# Altium schematic sheet VCU_Schematic.SchDoc(VCU_Schematic)
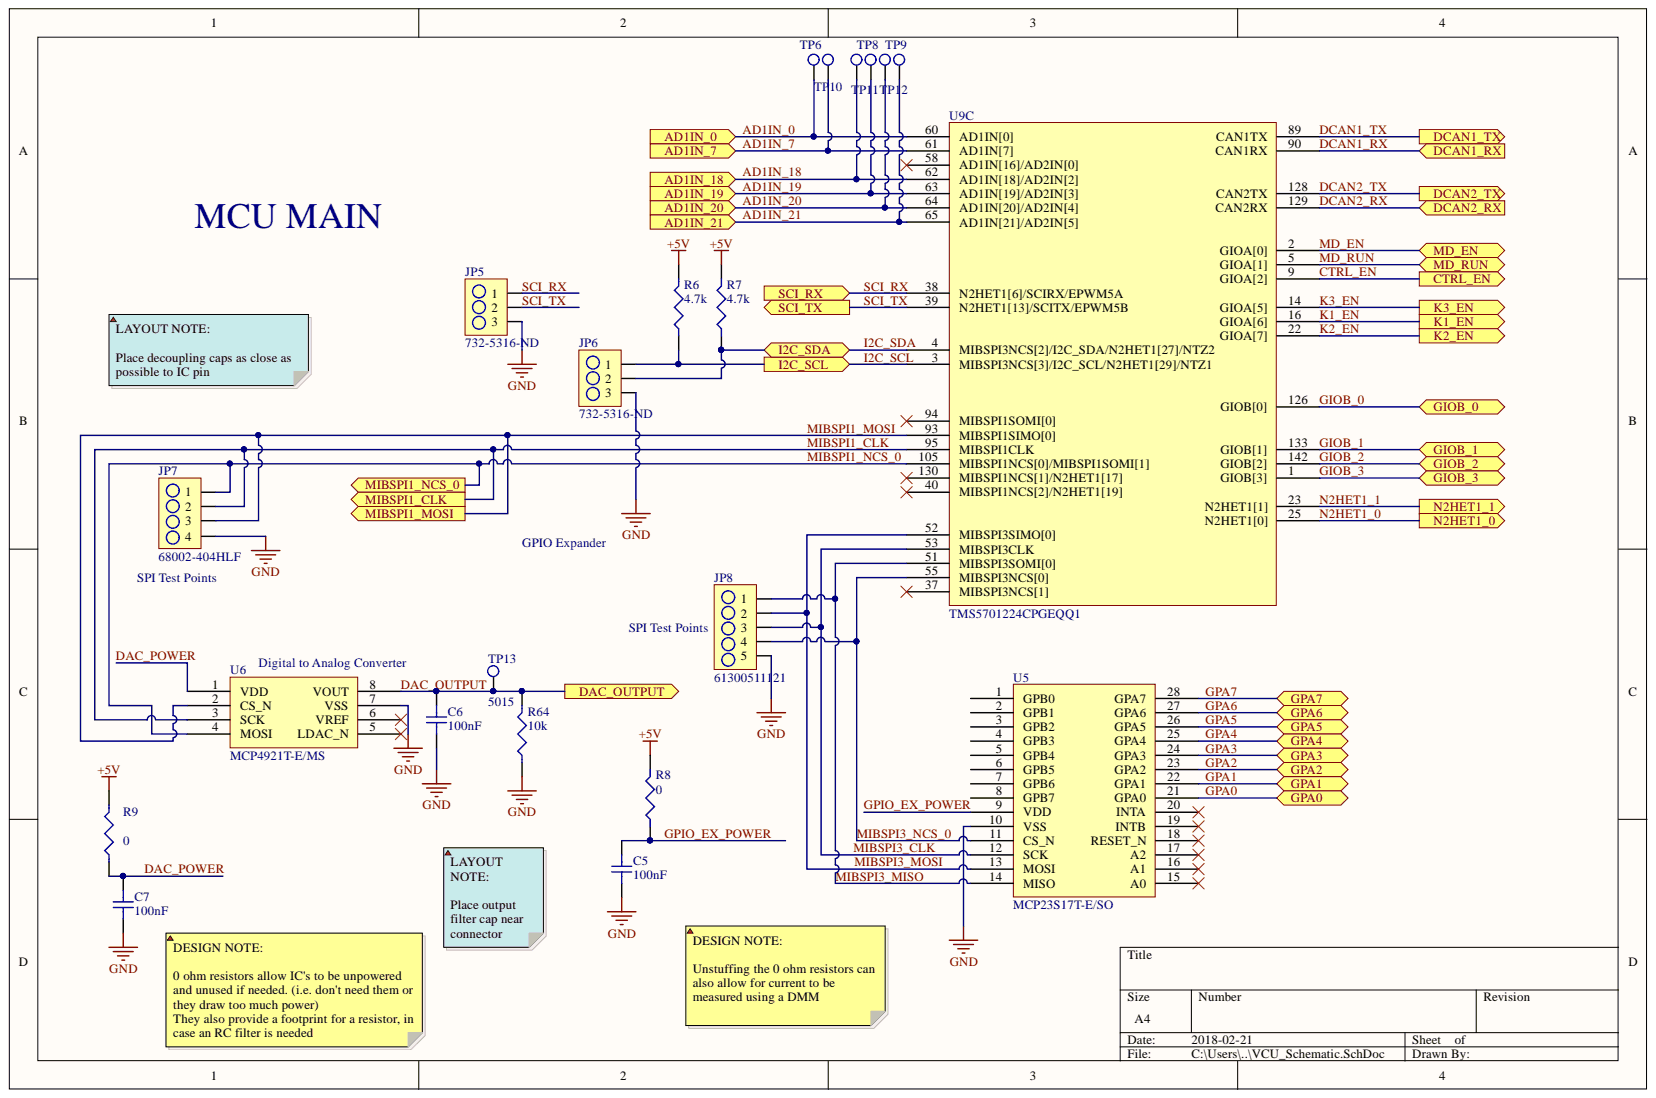
[diagram p0: VCU_Schematic.SchDoc]

## Parts
C5  100nF  [C0805]
C6  100nF  [C0805]
C7  100nF  [C0805]
JP5  732-5316-ND  [3PIN_HEADER]
JP6  732-5316-ND  [3PIN_HEADER]
JP7  68002-404HLF  [4PIN_HEADER]
JP8  61300511121  [5PIN_HEADER]
R6  4.7k  [R0805]
R7  4.7k  [R0805]
R8  0  [R0805]
R9  0  [R0805]
R64  10k  [R0805]
TP6  5015  [TP_HOOK]  (value not drawn)
TP8  5015  [TP_HOOK]  (value not drawn)
TP9  5015  [TP_HOOK]  (value not drawn)
TP10  5015  [TP_HOOK]  (value not drawn)
TP11  5015  [TP_HOOK]  (value not drawn)
TP12  5015  [TP_HOOK]  (value not drawn)
TP13  5015  [TP_HOOK]
U5  MCP23S17T-E/SO  [28-SOIC_MCP23S17]
U6  MCP4921T-E/MS  [8-MSOP_MCP4921]
U9  TMS5701224CPGEQQ1  [LQFP-144]  (part C)

## Ports
AD1IN_0, AD1IN_7, AD1IN_18, AD1IN_19, AD1IN_20, AD1IN_21, CTRL_EN, DAC_OUTPUT, DCAN1_RX, DCAN1_TX, DCAN2_RX, DCAN2_TX, GIOB_0, GIOB_1, GIOB_2, GIOB_3, GPA0, GPA1, GPA2, GPA3, GPA4, GPA5, GPA6, GPA7, I2C_SCL, I2C_SDA, K1_EN, K2_EN, K3_EN, MD_EN, MD_RUN, MIBSPI1_CLK, MIBSPI1_MOSI, MIBSPI1_NCS_0, N2HET1_0, N2HET1_1, SCI_RX, SCI_TX

## Nets
+5V: R6.2 R7.2 R8.2 R9.2
GND: C5.1 C6.1 C7.1 JP5.3 JP6.3 JP7.4 JP8.5 R64.1 U5.10(VSS) U6.7(VSS)
AD1IN_0: TP6.1 U9.60(AD1IN[0]) ; port AD1IN_0
AD1IN_7: TP10.1 U9.61(AD1IN[7]) ; port AD1IN_7
AD1IN_18: TP11.1 U9.62(AD1IN[18]/AD2IN[2]) ; port AD1IN_18
AD1IN_19: TP8.1 U9.63(AD1IN[19]/AD2IN[3]) ; port AD1IN_19
AD1IN_20: TP12.1 U9.64(AD1IN[20]/AD2IN[4]) ; port AD1IN_20
AD1IN_21: TP9.1 U9.65(AD1IN[21]/AD2IN[5]) ; port AD1IN_21
CTRL_EN: U9.9(GIOA[2]) ; port CTRL_EN
DAC_OUTPUT: C6.2 R64.2 TP13.1 U6.8(VOUT) ; port DAC_OUTPUT
DAC_POWER: C7.2 R9.1 U6.1(VDD)
DCAN1_RX: U9.90(CAN1RX) ; port DCAN1_RX
DCAN1_TX: U9.89(CAN1TX) ; port DCAN1_TX
DCAN2_RX: U9.129(CAN2RX) ; port DCAN2_RX
DCAN2_TX: U9.128(CAN2TX) ; port DCAN2_TX
GIOB_0: U9.126(GIOB[0]) ; port GIOB_0
GIOB_1: U9.133(GIOB[1]) ; port GIOB_1
GIOB_2: U9.142(GIOB[2]) ; port GIOB_2
GIOB_3: U9.1(GIOB[3]) ; port GIOB_3
GPA0: U5.21(GPA0) ; port GPA0
GPA1: U5.22(GPA1) ; port GPA1
GPA2: U5.23(GPA2) ; port GPA2
GPA3: U5.24(GPA3) ; port GPA3
GPA4: U5.25(GPA4) ; port GPA4
GPA5: U5.26(GPA5) ; port GPA5
GPA6: U5.27(GPA6) ; port GPA6
GPA7: U5.28(GPA7) ; port GPA7
GPIO_EX_POWER: C5.2 R8.1 U5.9(VDD)
I2C_SCL: JP6.1 R6.1 U9.3(MIBSPI3NCS[3]/I2C_SCL/N2HET1[29]/NTZ1) ; port I2C_SCL
I2C_SDA: JP6.2 R7.1 U9.4(MIBSPI3NCS[2]/I2C_SDA/N2HET1[27]/NTZ2) ; port I2C_SDA
K1_EN: U9.16(GIOA[6]) ; port K1_EN
K2_EN: U9.22(GIOA[7]) ; port K2_EN
K3_EN: U9.14(GIOA[5]) ; port K3_EN
MD_EN: U9.2(GIOA[0]) ; port MD_EN
MD_RUN: U9.5(GIOA[1]) ; port MD_RUN
MIBSPI1_CLK: JP7.2 U6.3(SCK) U9.95(MIBSPI1CLK) ; port MIBSPI1_CLK
MIBSPI1_MOSI: JP7.3 U6.2(CS_N) U9.93(MIBSPI1SIMO[0]) ; port MIBSPI1_MOSI
MIBSPI1_NCS_0: JP7.1 U6.4(MOSI) U9.105(MIBSPI1NCS[0]/MIBSPI1SOMI[1]) ; port MIBSPI1_NCS_0
MIBSPI3_CLK: JP8.3 U5.12(SCK) U9.53(MIBSPI3CLK)
MIBSPI3_MISO: JP8.1 U5.14(MISO) U9.51(MIBSPI3SOMI[0])
MIBSPI3_MOSI: JP8.2 U5.13(MOSI) U9.52(MIBSPI3SIMO[0])
MIBSPI3_NCS_0: JP8.4 U5.11(CS_N) U9.55(MIBSPI3NCS[0])
N2HET1_0: U9.25(N2HET1[0]) ; port N2HET1_0
N2HET1_1: U9.23(N2HET1[1]) ; port N2HET1_1
SCI_RX: JP5.1 U9.38(N2HET1[6]/SCIRX/EPWM5A) ; port SCI_RX
SCI_TX: JP5.2 U9.39(N2HET1[13]/SCITX/EPWM5B) ; port SCI_TX

Not connected: U5 1(GPB0) 2(GPB1) 3(GPB2) 4(GPB3) 5(GPB4) 6(GPB5) 7(GPB6) 8(GPB7) 15(A0) 16(A1) 17(A2) 18(RESET_N) 19(INTB) 20(INTA); U6 5(LDAC_N) 6(VREF); U9 37(MIBSPI3NCS[1]) 40(MIBSPI1NCS[2]/N2HET1[19]) 58(AD1IN[16]/AD2IN[0]) 94(MIBSPI1SOMI[0]) 130(MIBSPI1NCS[1]/N2HET1[17])

## Library data of the parts
C5-C7 = GRM21B7U1A104JA01L: CAP MLCC 100nF 10Volts U2J 5%; Manufacturer: Murata; Voltage Rating (DC): 10V; Width: 0.049inch; Voltage Rating: 10V; Tolerance: 5%; Thickness: 0.053inch; Termination: SMD/SMT; Supplier Part Number 1: 490-11592-6-ND; Supplier 1: Digi-Key; Stock 1: 6000; Radiation Hardening: No; Pricing 1: 1=0.46, 10=0.32, 100=0.192, 500=0.144, 1000=0.1216 (USD); Packaging: Tape and Reel; Package Quantity: 3000; Mount: Surface Mount; Min Operating Temperature: -55°C; Max Operating Temperature: 125°C; Manufacturer Part Number: GRM21B7U1A104JA01L; Length: 2mm; Height: 1.25mm; Dielectric: U2J; Depth: 1.25mm; ComponentLink3URL: https://datasheet.ciiva.com/11618/product-11618924.pdf; ComponentLink3Description: https://datasheet.ciiva.com/11618/product-11618924.pdf; ComponentLink2URL: https://datasheet.ciiva.com/17451/product-17451845.pdf; ComponentLink2Description: https://datasheet.ciiva.com/17451/product-17451845.pdf; ComponentLink1URL: https://datasheet.ciiva.com/5424/product-5424660.pdf; ComponentLink1Description: https://datasheet.ciiva.com/5424/product-5424660.pdf; Component Kind: Standard; Case Code (Metric): 2012; Case Code (Imperial): 0805; Case/Package: 0805; Capacitance: 100nF; Library Name: PHANTOM_LIBRARY.SchLib
JP5 JP6 = 61300311121: CONN_HeaderPins3pos WURTH ELEKTRONIK Board-To-Board Connector, Vertical, WR-PHD Series, Through Hole, Header, 3 Contacts, 2.54 mm; Manufacturer: Wurth Electronics; Pitch: 0.1inch; Number of Positions: 3; Gender: Male; Connector Type: Male Pin; Termination: Solder; Supplier Part Number 1: 732-5316-ND; Supplier 1: Digi-Key; Stock 1: 57026; REACH SVHC: Unknown; Pricing 1: 1=0.13, 10=0.106, 25=0.088, 50=0.074, 100=0.067, 1000=0.061 (USD); Packaging: Bulk; Number of Rows: 1; Number of Contacts: 3; Mount: Through Hole; Manufacturer Part Number: 61300311121; Lead Free: Lead Free; Contact Plating: Gold; Contact Mating Length: 0.236inch; Contact Material: Copper; ComponentLink1URL: http://www.farnell.com/cad/1737268.pdf; ComponentLink1Description: http://www.farnell.com/cad/1737268.pdf; Component Kind: Standard; Colour: Black; Case/Package: 100; Library Name: PHANTOM_LIBRARY.SchLib
JP7 = 68002-404HLF: CONN_HeaderPins4pos 4 Positions Header, Unshrouded Connector 0.100" (2.54mm) Through Hole Tin; Manufacturer: Amphenol FCI; Voltage Rating: 1.5kV; Number of Positions: 4; Mating Post Length: 5.84mm; Insulation Resistance: 5GR; Gender: Male; Current Rating: 3A; Connector Type: Male Pin; Termination Post Length: 3.81mm; Termination: Solder; Supplier Part Number 1: 609-3305-ND; Supplier 1: Digi-Key; Stock 1: 4956; Radiation Hardening: No; Pricing 1: 1=0.21, 10=0.195, 100=0.1352, 500=0.1144, 1000=0.0936, 5000=0.0832, 10000=0.0754 (USD); Pitch: 2.54mm; Packaging: Bulk; Package Quantity: 100; Orientation: Straight; Number of Rows: 1; Number of Contacts: 4; Mount: Through Hole; Min Operating Temperature: -65°C; Max Voltage Rating (AC): 1.5kV; Max Operating Temperature: 125°C; Manufacturer Part Number: 68002-404HLF; Length: 10.16mm; Housing Material: Thermoplastic; Housing Colour: Black; Flammability Rating: UL94 V-0; Depth: 2.41mm; Contact Plating: Nickel,Tin; Contact Mating Length: 0.23inch; Contact Material: Bronze; Contact Gender: Male; ComponentLink3URL: https://datasheet.ciiva.com/728/68000-728877.pdf; ComponentLink3Description: https://datasheet.ciiva.com/728/68000-728877.pdf; ComponentLink2URL: https://datasheet.ciiva.com/16725/68000-16725993.pdf; ComponentLink2Description: https://datasheet.ciiva.com/16725/68000-16725993.pdf; ComponentLink1URL: https://datasheet.ciiva.com/9474/68000-9474358.pdf; ComponentLink1Description: https://datasheet.ciiva.com/9474/68000-9474358.pdf; Component Kind: Standard; Colour: Black; Library Name: PHANTOM_LIBRARY.SchLib
JP8 = 61300511121: CONN_HeaderPins5pos WURTH ELEKTRONIK         61300511121            Board-To-Board Connector, Vertical, WR-PHD Series, Through Hole, Header, 5 Contacts, 2.54 mm; Manufacturer: Wurth Electronics; Pitch: 0.1inch; Number of Positions: 5; Gender: Male; Connector Type: Male Pin; Termination: Solder; Supplier Part Number 1: 732-5318-ND; Supplier 1: Digi-Key; Stock 1: 13004; REACH SVHC: Unknown; Pricing 1: 1=0.25, 10=0.209, 25=0.174, 50=0.145, 100=0.132, 1000=0.12 (USD); Packaging: Bulk; Number of Rows: 1; Number of Contacts: 5; Mount: Through Hole; Manufacturer Part Number: 61300511121; Lead Free: Lead Free; Contact Plating: Gold; Contact Mating Length: 0.236inch; Contact Material: Copper; ComponentLink1URL: http://www.farnell.com/cad/1737268.pdf; ComponentLink1Description: http://www.farnell.com/cad/1737268.pdf; Component Kind: Standard; Colour: Black; Library Name: PHANTOM_LIBRARY.SchLib
R6 R7 = RC0805FR-074K7L: RES SMD 4.7K OHM 1% 1/8W 0805; Manufacturer: Yageo; Voltage Rating (DC): 150V; Temperature Coefficient: 100ppm/°C; Resistance: 4.7KR; Power Rating: 125mW; Width: 0.049inch; Voltage Rating: 150V; Tolerance: 1%; Termination: SMD/SMT; Supplier Part Number 1: 311-4.70KCRDKR-ND; Supplier 1: Digi-Key; Stock 1: 2632902; REACH SVHC: No SVHC; Pricing 1: 1=0.1, 10=0.022, 100=0.0088, 1000=0.004, 2500=0.0034 (USD); Packaging: Tape and Reel; Package Quantity: 5000; Number of Terminations: 2; Number of Pins: 2; Min Operating Temperature: -55°C; Military Standard: Not; Max Power Dissipation: 125mW; Max Operating Temperature: 155°C; Manufacturer Part Number: RC0805FR-074K7L; Length: 0.079inch; Lead Free: Lead Free; Height: 0.024inch; Depth: 1.25mm; Composition: Thick Film; ComponentLink6URL: https://datasheet.ciiva.com/46447/444164-46447583.pdf; ComponentLink6Description: https://datasheet.ciiva.com/46447/444164-46447583.pdf; ComponentLink5URL: https://datasheet.ciiva.com/6547/pyu-r-int-thick-7-6547263.pdf; ComponentLink5Description: https://datasheet.ciiva.com/6547/pyu-r-int-thick-7-6547263.pdf; ComponentLink4URL: https://datasheet.ciiva.com/7941/444164-7941806.pdf; ComponentLink4Description: https://datasheet.ciiva.com/7941/444164-7941806.pdf; ComponentLink3URL: https://datasheet.ciiva.com/7941/1686128-7941805.pdf; ComponentLink3Description: https://datasheet.ciiva.com/7941/1686128-7941805.pdf; ComponentLink2URL: https://datasheet.ciiva.com/7941/444164-7941804.pdf; ComponentLink2Description: https://datasheet.ciiva.com/7941/444164-7941804.pdf; ComponentLink1URL: https://datasheet.ciiva.com/11808/0900766b8106beab-11808155.pdf; ComponentLink1Description: https://datasheet.ciiva.com/11808/0900766b8106beab-11808155.pdf; Component Kind: Standard; Case Code (Metric): 2012; Case Code (Imperial): 0805; Case/Package: 0805; Library Name: PHANTOM_LIBRARY.SchLib
R8 R9 = RC0805JR-070RL: RES SMD 0 OHM 1/8W 0805; Manufacturer: Yageo; Voltage Rating (DC): 150V; Tolerance: 5%; Temperature Coefficient: 200ppm/°C; Resistance: 0mR; Power Rating: 125mW; Width: 1.25mm; Voltage Rating: 150V; Termination: SMD/SMT; Supplier Part Number 1: 311-0.0ARDKR-ND; Supplier 1: Digi-Key; Stock 1: 5922085; REACH SVHC: Unknown; Radiation Hardening: No; Pricing 1: 1=0.1, 10=0.018, 25=0.0128, 50=0.0096, 100=0.0071, 250=0.0054, 500=0.0044, 1000=0.0032 (USD); Packaging: Tape and Reel; Number of Pins: 2; Min Operating Temperature: -55°C; Military Standard: Not; Max Operating Temperature: 155°C; Manufacturer Part Number: RC0805JR-070RL; Length: 2mm; Lead Free: Lead Free; Height: 0.5mm; Depth: 1.25mm; Contact Plating: Tin,Matte; Composition: Thick Film; ComponentLink5URL: https://datasheet.ciiva.com/15190/getdatasheetpartid-330685-15190028.pdf; ComponentLink5Description: https://datasheet.ciiva.com/15190/getdatasheetpartid-330685-15190028.pdf; ComponentLink4URL: https://datasheet.ciiva.com/7941/1738694-7941863.pdf; ComponentLink4Description: https://datasheet.ciiva.com/7941/1738694-7941863.pdf; ComponentLink3URL: https://datasheet.ciiva.com/11819/0900766b8106beab-11819430.pdf; ComponentLink3Description: https://datasheet.ciiva.com/11819/0900766b8106beab-11819430.pdf; ComponentLink2URL: https://datasheet.ciiva.com/7941/1738694-7941862.pdf; ComponentLink2Description: https://datasheet.ciiva.com/7941/1738694-7941862.pdf; ComponentLink1URL: https://datasheet.ciiva.com/7941/1738694-7941861.pdf; ComponentLink1Description: https://datasheet.ciiva.com/7941/1738694-7941861.pdf; Component Kind: Standard; Case Code (Metric): 2012; Case Code (Imperial): 0805; Case/Package: 0805; Library Name: PHANTOM_LIBRARY.SchLib
R64 = RC0805FR-071KL: RES SMD 10K OHM 1% 1/8W 0805; Manufacturer: Yageo Phycomp; Voltage Rating (DC): 150V; Temperature Coefficient: 100ppm/°C; Resistance: 1KR; Power Rating: 125mW; Width: 1.25mm; Tolerance: 1%; Supplier 1: Digi-Key; Stock 1: 5527973; REACH SVHC: No SVHC; Pricing 1: 1=0.1, 10=0.022, 100=0.0088, 1000=0.004, 2500=0.0034 (USD); Packaging: Cut Tape; Number of Pins: 2; Min Operating Temperature: -55°C; Max Operating Temperature: 155°C; Manufacturer Part Number: RC0805FR-071KL; Length: 2mm; Lead Free: Lead Free; Height: 0.5mm; Depth: 1.25mm; Component Kind: Standard; Case/Package: 0805; Library Name: PHANTOM_LIBRARY.SchLib
TP6 TP8-TP13 = 5015: TEST POINT, PCB, SMT; Manufacturer: Keystone Electronics; Number of Ports: 1; Thickness: 0.01inch; Termination: Solder; Supplier Part Number 1: 36-5015CT-ND; Supplier 1: Digi-Key; Stock 1: 1937775; REACH SVHC: No SVHC; Pricing 1: 1=0.44, 10=0.421, 25=0.3604, 50=0.3064, 100=0.2944, 250=0.2644, 500=0.2524 (USD); Packaging: Tape and Reel; Package Quantity: 1; Orientation: Straight; Mount: Surface Mount; Material: Bronze,Silver; Manufacturer Part Number: 5015; Length: 2.66mm; Lead Free: Lead Free; Height: 0.06inch; Depth: 1.01mm; Contact Plating: Tin,Silver,Lead; Contact Material: Bronze; ComponentLink4URL: https://datasheet.ciiva.com/16883/70182998-16883734.pdf; ComponentLink4Description: https://datasheet.ciiva.com/16883/70182998-16883734.pdf; ComponentLink3URL: https://datasheet.ciiva.com/1123/5015-1123862.pdf; ComponentLink3Description: https://datasheet.ciiva.com/1123/5015-1123862.pdf; ComponentLink2URL: https://datasheet.ciiva.com/2917/5015-2917617.pdf; ComponentLink2Description: https://datasheet.ciiva.com/2917/5015-2917617.pdf; ComponentLink1URL: https://datasheet.ciiva.com/2917/5015-2917616.pdf; ComponentLink1Description: https://datasheet.ciiva.com/2917/5015-2917616.pdf; Component Kind: Standard; Colour: White; Library Name: PHANTOM_LIBRARY.SchLib
U5 = MCP23S17T-E/SO: IC I/O Expander, 16bit, 10 MHz, SPI, 4.5 V, 5.5 V, SOIC; Manufacturer: Microchip; Power Dissipation: 700mW; Number of I/Os: 16; Number of Channels: 1; Nominal Supply Current: 1mA; Min Supply Voltage: 4.5V; Max Supply Voltage: 5.5V; Max Frequency: 10MHz; Interface: SPI,Serial; Supplier Part Number 1: MCP23S17T-E/SODKR-ND; Supplier 1: Digi-Key; Stock 1: 6929; REACH SVHC: No SVHC; Pricing 1: 1=1.32, 25=1.1024, 100=0.9975 (USD); Packaging: Tape and Reel; Package Quantity: 1600; Number of Pins: 28; Number of Bits: 16; Mount: Surface Mount; Min Operating Temperature: -40°C; Max Operating Temperature: 125°C; Manufacturer Part Number: MCP23S17T-E/SO; Lead Free: Lead Free; Frequency: 10MHz; ComponentLink6URL: https://datasheet.ciiva.com/12266/21952b-12266927.pdf; ComponentLink6Description: https://datasheet.ciiva.com/12266/21952b-12266927.pdf; ComponentLink5URL: https://datasheet.ciiva.com/1505/21952b-1505037.pdf; ComponentLink5Description: https://datasheet.ciiva.com/1505/21952b-1505037.pdf; ComponentLink4URL: https://datasheet.ciiva.com/16617/21952b-16617644.pdf; ComponentLink4Description: https://datasheet.ciiva.com/16617/21952b-16617644.pdf; ComponentLink3URL: https://datasheet.ciiva.com/16221/filehandler-aspxddocname-en023709-16221082.pdf; ComponentLink3Description: https://datasheet.ciiva.com/16221/filehandler-aspxddocname-en023709-16221082.pdf; ComponentLink2URL: https://datasheet.ciiva.com/1495/12179-1495927.pdf; ComponentLink2Description: https://datasheet.ciiva.com/1495/12179-1495927.pdf; ComponentLink1URL: https://datasheet.ciiva.com/1780/21952b-1780739.pdf; ComponentLink1Description: https://datasheet.ciiva.com/1780/21952b-1780739.pdf; Component Kind: Standard; Case/Package: SOIC; Library Name: PHANTOM_LIBRARY.SchLib
U6 = MCP4921T-E/MS: IC Digital to Analog Converters - DAC Sgl 12-bit SPI int; Manufacturer: Microchip; Supply Voltage: 5V; Supply Type: Single; Settling Time: 4.5us; Resolution: 12b; Number of DAC Channels: 1; Number of Bits: 12; Nominal Supply Current: 175uA; Min Supply Voltage: 2.7V; Max Supply Voltage: 5.5V; Interface: SPI,Serial; Integral Nonlinearity (INL): 12LSB; Input Offset Voltage (Vos): 820uV; Supplier Part Number 1: MCP4921T-E/MSCT-ND; Supplier 1: Digi-Key; Stock 1: 5999; REACH SVHC: No SVHC; Radiation Hardening: No; Pricing 1: 1=2.07, 25=1.722, 100=1.5645 (USD); Packaging: Tape and Reel; Package Quantity: 1; Number of Pins: 8; Number of Converters: 1; Number of Channels: 1; Mount: Surface Mount; Min Operating Temperature: -40°C; Max Operating Temperature: 125°C; Manufacturer Part Number: MCP4921T-E/MS; Lead Free: Lead Free; ComponentLink7URL: https://datasheet.ciiva.com/41459/001083287-da-01-en-ic-dac-12-w-spi-mcp4921t-e-ms-msop-8-mcp-41459046.pdf; ComponentLink7Description: https://datasheet.ciiva.com/41459/001083287-da-01-en-ic-dac-12-w-spi-mcp4921t-e-ms-msop-8-mcp-41459046.pdf; ComponentLink6URL: https://datasheet.ciiva.com/6666/22248a-6666558.pdf; ComponentLink6Description: https://datasheet.ciiva.com/6666/22248a-6666558.pdf; ComponentLink5URL: https://datasheet.ciiva.com/16203/filehandler-aspxddocname-en547911-16203277.pdf; ComponentLink5Description: https://datasheet.ciiva.com/16203/filehandler-aspxddocname-en547911-16203277.pdf; ComponentLink4URL: https://datasheet.ciiva.com/16625/22248a-16625692.pdf; ComponentLink4Description: https://datasheet.ciiva.com/16625/22248a-16625692.pdf; ComponentLink3URL: https://datasheet.ciiva.com/8035/22248a-8035052.pdf; ComponentLink3Description: https://datasheet.ciiva.com/8035/22248a-8035052.pdf; ComponentLink2URL: https://datasheet.ciiva.com/6666/22248a-6666553.pdf; ComponentLink2Description: https://datasheet.ciiva.com/6666/22248a-6666553.pdf; ComponentLink1URL: https://datasheet.ciiva.com/3041/22248a-3041510.pdf; ComponentLink1Description: https://datasheet.ciiva.com/3041/22248a-3041510.pdf; Component Kind: Standard; Case/Package: MSOP; Library Name: PHANTOM_LIBRARY.SchLib
U9 = TMS5701224CPGEQQ1: ARM CORTEX R4 LOCK STEP HERCULES MCU; Manufacturer: Texas Instruments; Watchdog Timer: Yes; RAM Size: 192KB; Peripherals: POR,PWM,WDT,DMA; Oscillator Type: External; Number of Timers/Counters: 2; Number of Programmable I/O: 64; Memory Type: FLASH; Memory Size: 1.25MB; Max Frequency: 160MHz; Interface: I2C,SPI,CAN,UART,USART,LIN,SCI,EBI/EMI; Density: 1310720B; Supplier Part Number 1: 296-47200-ND; Supplier 1: Digi-Key; Stock 1: 113; Pricing 1: 1=22.12, 10=20.402, 100=17.4222, 500=15.8176 (USD); Packaging: Tray; Number of Pins: 144; Number of I/Os: 64; Number of GPIO: 64; Min Operating Temperature: -40°C; Max Operating Temperature: 125°C; Manufacturer Part Number: TMS5701224CPGEQQ1; Lead Free: Lead Free; Frequency: 160MHz; Core Architecture: ARM; ComponentLink3URL: http://www.ti.com/general/docs/lit/getliterature.tsp?genericPartNumber=TMS570LS1224&&fileType=pdf; ComponentLink3Description: http://www.ti.com/general/docs/lit/getliterature.tsp?genericPartNumber=TMS570LS1224&&fileType=pdf; ComponentLink2URL: http://www.ti.com/lit/gpn/tms570ls1224; ComponentLink2Description: http://www.ti.com/lit/gpn/tms570ls1224; ComponentLink1URL: http://www.ti.com/lit/pdf/spnu515; ComponentLink1Description: http://www.ti.com/lit/pdf/spnu515; Component Kind: Standard; Case/Package: LQFP; Library Name: PHANTOM_LIBRARY.SchLib
